# Altium schematic sheet Devices1.SchDoc(Devices1)
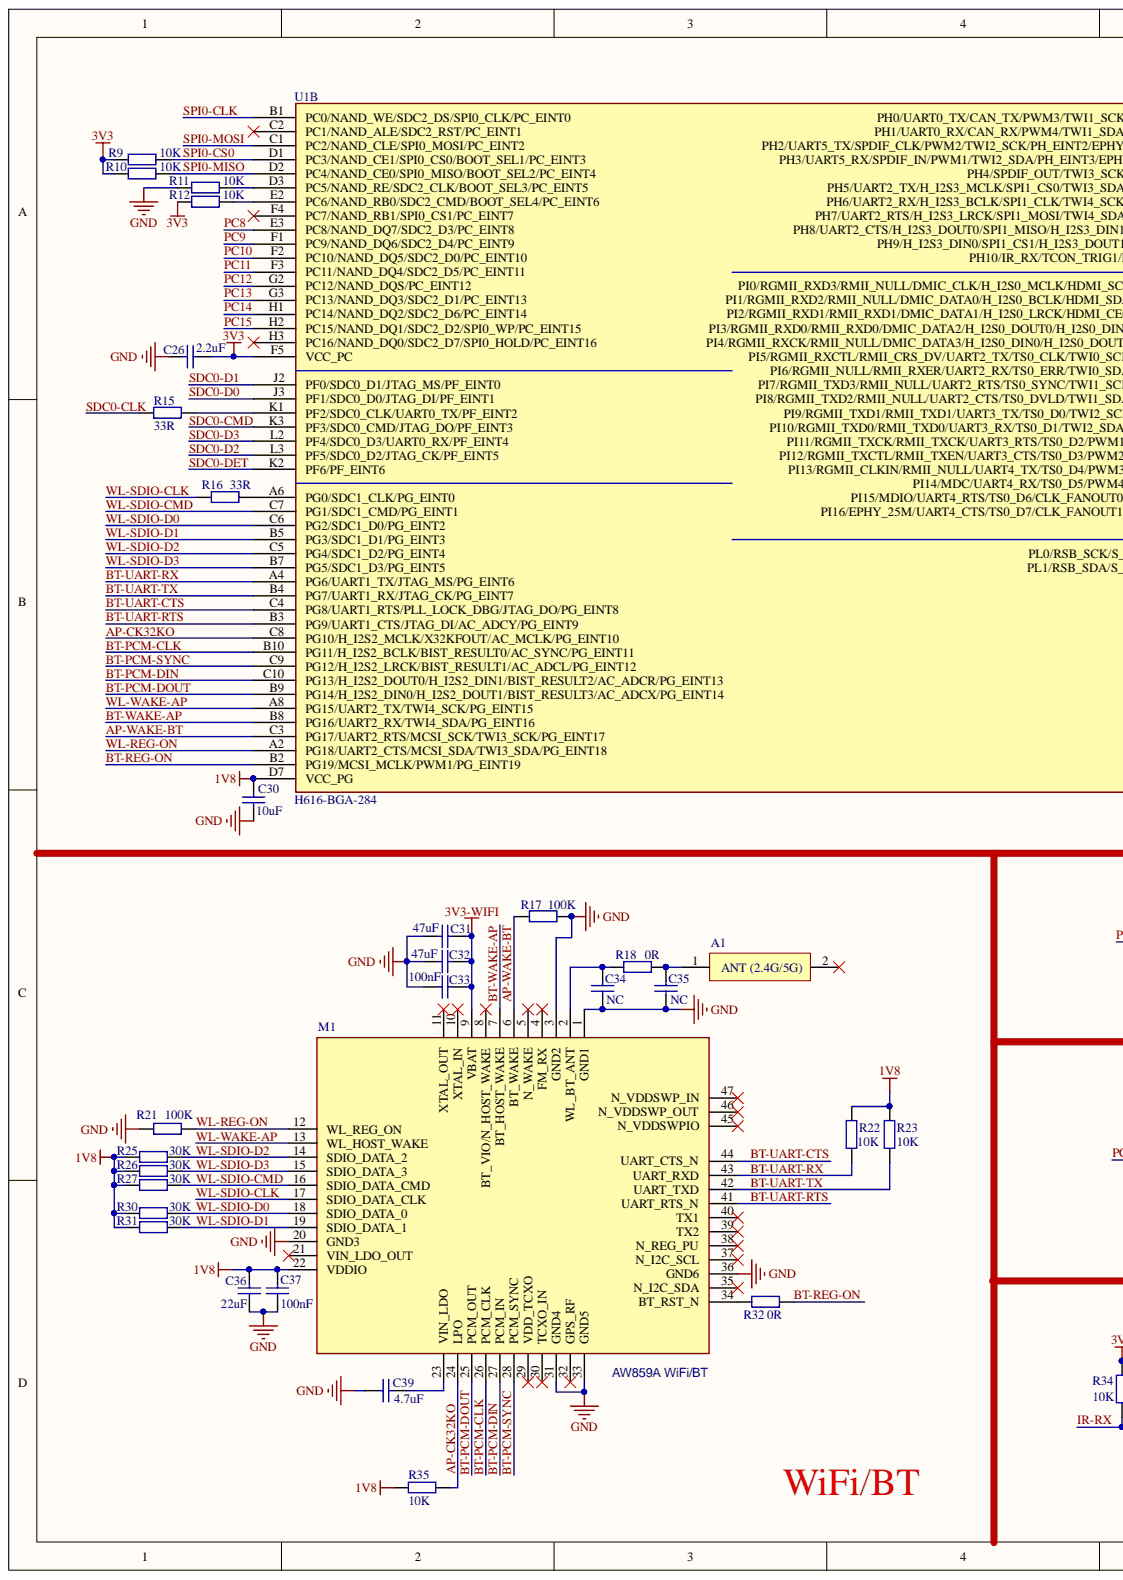
[diagram p0: Devices1.SchDoc, left]
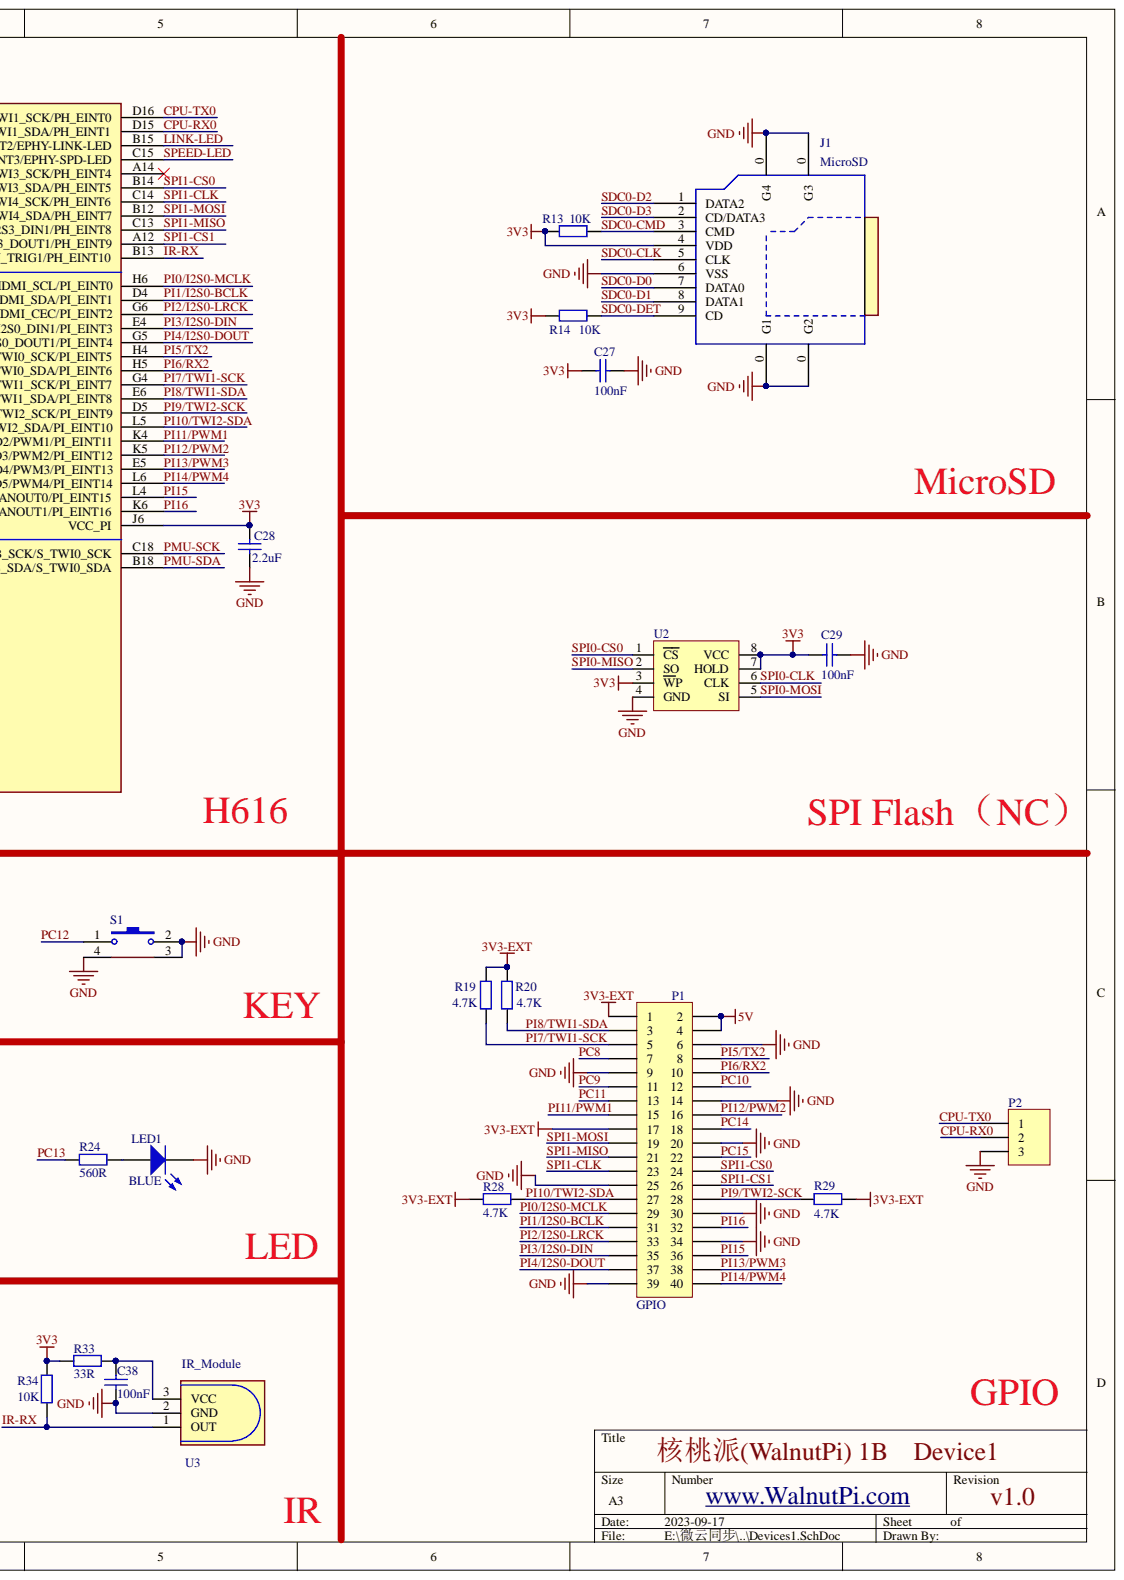
[diagram p1: Devices1.SchDoc, right]

## Parts
A1  (no value)  [OA-W01]
C26  2.2uF  [R0402]
C27  100nF  [R0402]
C28  2.2uF  [R0402]
C29  100nF  [R0402]
C30  10uF  [R0402]
C31  47uF  [R0603]
C32  47uF  [R0603]
C33  100nF  [R0402]
C34  NC  [R0402]
C35  NC  [R0402]
C36  22uF  [R0603]
C37  100nF  [R0402]
C38  100nF  [R0402]
C39  4.7uF  [R0402]
J1  MicroSD  [MicroSD卡]
LED1  BLUE  [D0603]
M1  AW859A WiFi/BT  [AW859A]
P1  GPIO  [HDR2X20（40P）]
P2  (no value)  [HDR1X3]
R9  10K  [R0402]
R10  10K  [R0402]
R11  10K  [R0402]
R12  10K  [R0402]
R13  10K  [R0402]
R14  10K  [R0402]
R15  33R  [R0402]
R16  33R  [R0402]
R17  100K  [R0402]
R18  0R  [R0402]
R19  4.7K  [R0402]
R20  4.7K  [R0402]
R21  100K  [R0402]
R22  10K  [R0402]
R23  10K  [R0402]
R24  560R  [R0402]
R25  30K  [R0402]
R26  30K  [R0402]
R27  30K  [R0402]
R28  4.7K  [R0402]
R29  4.7K  [R0402]
R30  30K  [R0402]
R31  30K  [R0402]
R32  0R  [R0402]
R33  33R  [R0402]
R34  10K  [R0402]
R35  10K  [R0402]
S1  (no value)  [3x6x3.5侧贴按键]
U1  H616-BGA-284  (part B)
U2  (no value)  [SOIC-8]
U3  IR_Module  [IR-RX]

## Nets
1V8: C30.2 C36.1 C37.2 M1.22(VDDIO) R22.1 R23.1 R25.2 R26.2 R27.2 R30.2 R31.2 R35.2 U1.D7(VCC_PG)
3V3: C26.2 C27.1 C28.2 C29.1 J1.4(VDD) R9.1 R10.1 R12.1 R13.2 R14.2 R33.1 R34.2 U1.F5(VCC_PC) U1.J6(VCC_PI) U2.3(WP) U2.7(HOLD) U2.8(VCC)
3V3-EXT: P1.1 P1.17 R19.2 R20.2 R28.2 R29.1
3V3-WIFI: C31.2 C32.2 C33.2 M1.9(VBAT)
5V: P1.2 P1.4
GND: C26.1 C27.2 C28.1 C29.2 C30.1 C31.1 C32.1 C33.1 C34.1 C35.1 C36.2 C37.1 C38.1 C39.1 J1.0(G4) J1.6(VSS) LED1.2 M1.1(GND1) M1.3(GND2) M1.20(GND3) M1.31(GND4) M1.33(GND5) M1.36(GND6) P1.6 P1.9 P1.14 P1.20 P1.25 P1.30 P1.34 P1.39 P2.3 R11.1 R17.1 R21.2 S1.2 S1.3 S1.4 U2.4(GND) U3.2
AP-CK32KO: M1.24(LPO) R35.1 U1.C8(PG10/H_I2S2_MCLK/X32KFOUT/AC_MCLK/PG_EINT10)
AP-WAKE-BT: M1.6(BT_WAKE) R17.2 U1.C3(PG17/UART2_RTS/MCSI_SCK/TWI3_SCK/PG_EINT17)
BT-PCM-CLK: M1.26(PCM_CLK) U1.B10(PG11/H_I2S2_BCLK/BIST_RESULT0/AC_SYNC/PG_EINT11)
BT-PCM-DIN: M1.27(PCM_IN) U1.C10(PG13/H_I2S2_DOUT0/H_I2S2_DIN1/BIST_RESULT2/AC_ADCR/PG_EINT13)
BT-PCM-DOUT: M1.25(PCM_OUT) U1.B9(PG14/H_I2S2_DIN0/H_I2S2_DOUT1/BIST_RESULT3/AC_ADCX/PG_EINT14)
BT-PCM-SYNC: M1.28(PCM_SYNC) U1.C9(PG12/H_I2S2_LRCK/BIST_RESULT1/AC_ADCL/PG_EINT12)
BT-REG-ON: R32.2 U1.B2(PG19/MCSI_MCLK/PWM1/PG_EINT19)
BT-UART-CTS: M1.44(UART_CTS_N) U1.C4(PG8/UART1_RTS/PLL_LOCK_DBG/JTAG_DO/PG_EINT8)
BT-UART-RTS: M1.41(UART_RTS_N) U1.B3(PG9/UART1_CTS/JTAG_DI/AC_ADCY/PG_EINT9)
BT-UART-RX: M1.43(UART_RXD) R22.2 U1.A4(PG6/UART1_TX/JTAG_MS/PG_EINT6)
BT-UART-TX: M1.42(UART_TXD) R23.2 U1.B4(PG7/UART1_RX/JTAG_CK/PG_EINT7)
BT-WAKE-AP: M1.7(BT_HOST_WAKE) U1.B8(PG16/UART2_RX/TWI4_SDA/PG_EINT16)
CPU-RX0: P2.2 U1.D15(PH1/UART0_RX/CAN_RX/PWM4/TWI1_SDA/PH_EINT1)
CPU-TX0: P2.1 U1.D16(PH0/UART0_TX/CAN_TX/PWM3/TWI1_SCK/PH_EINT0)
IR-RX: R34.1 U1.B13(PH10/IR_RX/TCON_TRIG1/PH_EINT10) U3.1
LINK-LED: U1.B15(PH2/UART5_TX/SPDIF_CLK/PWM2/TWI2_SCK/PH_EINT2/EPHY-LINK-LED)
PC8: P1.7 U1.E3(PC8/NAND_DQ7/SDC2_D3/PC_EINT8)
PC9: P1.11 U1.F1(PC9/NAND_DQ6/SDC2_D4/PC_EINT9)
PC10: P1.12 U1.F2(PC10/NAND_DQ5/SDC2_D0/PC_EINT10)
PC11: P1.13 U1.F3(PC11/NAND_DQ4/SDC2_D5/PC_EINT11)
PC12: S1.1 U1.G2(PC12/NAND_DQS/PC_EINT12)
PC13: R24.1 U1.G3(PC13/NAND_DQ3/SDC2_D1/PC_EINT13)
PC14: P1.18 U1.H1(PC14/NAND_DQ2/SDC2_D6/PC_EINT14)
PC15: P1.22 U1.H2(PC15/NAND_DQ1/SDC2_D2/SPI0_WP/PC_EINT15)
PI0/I2S0-MCLK: P1.29 U1.H6(PI0/RGMII_RXD3/RMII_NULL/DMIC_CLK/H_I2S0_MCLK/HDMI_SCL/PI_EINT0)
PI1/I2S0-BCLK: P1.31 U1.D4(PI1/RGMII_RXD2/RMII_NULL/DMIC_DATA0/H_I2S0_BCLK/HDMI_SDA/PI_EINT1)
PI2/I2S0-LRCK: P1.33 U1.G6(PI2/RGMII_RXD1/RMII_RXD1/DMIC_DATA1/H_I2S0_LRCK/HDMI_CEC/PI_EINT2)
PI3/I2S0-DIN: P1.35 U1.E4(PI3/RGMII_RXD0/RMII_RXD0/DMIC_DATA2/H_I2S0_DOUT0/H_I2S0_DIN1/PI_EINT3)
PI4/I2S0-DOUT: P1.37 U1.G5(PI4/RGMII_RXCK/RMII_NULL/DMIC_DATA3/H_I2S0_DIN0/H_I2S0_DOUT1/PI_EINT4)
PI5/TX2: P1.8 U1.H4(PI5/RGMII_RXCTL/RMII_CRS_DV/UART2_TX/TS0_CLK/TWI0_SCK/PI_EINT5)
PI6/RX2: P1.10 U1.H5(PI6/RGMII_NULL/RMII_RXER/UART2_RX/TS0_ERR/TWI0_SDA/PI_EINT6)
PI7/TWI1-SCK: P1.5 R19.1 U1.G4(PI7/RGMII_TXD3/RMII_NULL/UART2_RTS/TS0_SYNC/TWI1_SCK/PI_EINT7)
PI8/TWI1-SDA: P1.3 R20.1 U1.E6(PI8/RGMII_TXD2/RMII_NULL/UART2_CTS/TS0_DVLD/TWI1_SDA/PI_EINT8)
PI9/TWI2-SCK: P1.28 R29.2 U1.D5(PI9/RGMII_TXD1/RMII_TXD1/UART3_TX/TS0_D0/TWI2_SCK/PI_EINT9)
PI10/TWI2-SDA: P1.27 R28.1 U1.L5(PI10/RGMII_TXD0/RMII_TXD0/UART3_RX/TS0_D1/TWI2_SDA/PI_EINT10)
PI11/PWM1: P1.15 U1.K4(PI11/RGMII_TXCK/RMII_TXCK/UART3_RTS/TS0_D2/PWM1/PI_EINT11)
PI12/PWM2: P1.16 U1.K5(PI12/RGMII_TXCTL/RMII_TXEN/UART3_CTS/TS0_D3/PWM2/PI_EINT12)
PI13/PWM3: P1.38 U1.E5(PI13/RGMII_CLKIN/RMII_NULL/UART4_TX/TS0_D4/PWM3/PI_EINT13)
PI14/PWM4: P1.40 U1.L6(PI14/MDC/UART4_RX/TS0_D5/PWM4/PI_EINT14)
PI15: P1.36 U1.L4(PI15/MDIO/UART4_RTS/TS0_D6/CLK_FANOUT0/PI_EINT15)
PI16: P1.32 U1.K6(PI16/EPHY_25M/UART4_CTS/TS0_D7/CLK_FANOUT1/PI_EINT16)
PMU-SCK: U1.C18(PL0/RSB_SCK/S_TWI0_SCK)
PMU-SDA: U1.B18(PL1/RSB_SDA/S_TWI0_SDA)
SDC0-CLK: J1.5(CLK) R15.1
SDC0-CMD: J1.3(CMD) R13.1 U1.K3(PF3/SDC0_CMD/JTAG_DO/PF_EINT3)
SDC0-D0: J1.7(DATA0) U1.J3(PF1/SDC0_D0/JTAG_DI/PF_EINT1)
SDC0-D1: J1.8(DATA1) U1.J2(PF0/SDC0_D1/JTAG_MS/PF_EINT0)
SDC0-D2: J1.1(DATA2) U1.L3(PF5/SDC0_D2/JTAG_CK/PF_EINT5)
SDC0-D3: J1.2(CD/DATA3) U1.L2(PF4/SDC0_D3/UART0_RX/PF_EINT4)
SDC0-DET: J1.9(CD) R14.1 U1.K2(PF6/PF_EINT6)
SPEED-LED: U1.C15(PH3/UART5_RX/SPDIF_IN/PWM1/TWI2_SDA/PH_EINT3/EPHY-SPD-LED)
SPI0-CLK: U1.B1(PC0/NAND_WE/SDC2_DS/SPI0_CLK/PC_EINT0) U2.6(CLK)
SPI0-CS0: R9.2 U1.D1(PC3/NAND_CE1/SPI0_CS0/BOOT_SEL1/PC_EINT3) U2.1(CS)
SPI0-MISO: R10.2 U1.D2(PC4/NAND_CE0/SPI0_MISO/BOOT_SEL2/PC_EINT4) U2.2(SO)
SPI0-MOSI: U1.C1(PC2/NAND_CLE/SPI0_MOSI/PC_EINT2) U2.5(SI)
SPI1-CLK: P1.23 U1.C14(PH6/UART2_RX/H_I2S3_BCLK/SPI1_CLK/TWI4_SCK/PH_EINT6)
SPI1-CS0: P1.24 U1.B14(PH5/UART2_TX/H_I2S3_MCLK/SPI1_CS0/TWI3_SDA/PH_EINT5)
SPI1-CS1: P1.26 U1.A12(PH9/H_I2S3_DIN0/SPI1_CS1/H_I2S3_DOUT1/PH_EINT9)
SPI1-MISO: P1.21 U1.C13(PH8/UART2_CTS/H_I2S3_DOUT0/SPI1_MISO/H_I2S3_DIN1/PH_EINT8)
SPI1-MOSI: P1.19 U1.B12(PH7/UART2_RTS/H_I2S3_LRCK/SPI1_MOSI/TWI4_SDA/PH_EINT7)
WL-REG-ON: M1.12(WL_REG_ON) R21.1 U1.A2(PG18/UART2_CTS/MCSI_SDA/TWI3_SDA/PG_EINT18)
WL-SDIO-CLK: M1.17(SDIO_DATA_CLK) R16.1
WL-SDIO-CMD: M1.16(SDIO_DATA_CMD) R27.1 U1.C7(PG1/SDC1_CMD/PG_EINT1)
WL-SDIO-D0: M1.18(SDIO_DATA_0) R30.1 U1.C6(PG2/SDC1_D0/PG_EINT2)
WL-SDIO-D1: M1.19(SDIO_DATA_1) R31.1 U1.B5(PG3/SDC1_D1/PG_EINT3)
WL-SDIO-D2: M1.14(SDIO_DATA_2) R25.1 U1.C5(PG4/SDC1_D2/PG_EINT4)
WL-SDIO-D3: M1.15(SDIO_DATA_3) R26.1 U1.B7(PG5/SDC1_D3/PG_EINT5)
WL-WAKE-AP: M1.13(WL_HOST_WAKE) U1.A8(PG15/UART2_TX/TWI4_SCK/PG_EINT15)
NetA1_1: A1.1 C35.2 R18.1
NetC34_2: C34.2 M1.2(WL_BT_ANT) R18.2
NetC38_2: C38.2 R33.2 U3.3
NetC39_2: C39.2 M1.23(VIN_LDO)
NetLED1_1: LED1.1 R24.2
NetM1_34: M1.34(BT_RST_N) R32.1
NetR11_2: R11.2 U1.D3(PC5/NAND_RE/SDC2_CLK/BOOT_SEL3/PC_EINT5)
NetR12_2: R12.2 U1.E2(PC6/NAND_RB0/SDC2_CMD/BOOT_SEL4/PC_EINT6)
NetR15_2: R15.2 U1.K1(PF2/SDC0_CLK/UART0_TX/PF_EINT2)
NetR16_2: R16.2 U1.A6(PG0/SDC1_CLK/PG_EINT0)

Not connected: A1 2; M1 4(FM_RX) 5(N_WAKE) 8(BT_VIO/N_HOST_WAKE) 10(XTAL_IN) 11(XTAL_OUT) 21(VIN_LDO_OUT) 29(VDD_TCXO) 30(TCXO_IN) 32(GPS_RF) 35(N_I2C_SDA) 37(N_I2C_SCL) 38(N_REG_PU) 39(TX2) 40(TX1) 45(N_VDDSWPIO) 46(N_VDDSWP_OUT) 47(N_VDDSWP_IN); U1 A14(PH4/SPDIF_OUT/TWI3_SCK/PH_EINT4) C2(PC1/NAND_ALE/SDC2_RST/PC_EINT1) F4(PC7/NAND_RB1/SPI0_CS1/PC_EINT7) H3(PC16/NAND_DQ0/SDC2_D7/SPI0_HOLD/PC_EINT16)

## Library data of the parts
A1 = SMT_ANT_双频: Library Name: Schlib1.SchLib; Component Kind: Standard
C26-C39 = Cap: Capacitor; Simulation: CAP; Signal Integrity: Cap; Published: 8-Jun-2000; PackageReference: RAD-0.3; Library Name: Miscellaneous Devices.IntLib; LatestRevisionNote: Re-released for DXP Platform.; LatestRevisionDate: 17-Jul-2002; Component Kind: Standard; Publisher: Altium Limited
J1 = TF_SD_SOCKET_0: Library Name: Schlib1.SchLib; Component Kind: Standard
LED1 = LED0: Typical INFRARED GaAs LED; Simulation: LED0; Published: 8-Jun-2000; PackageReference: LED-0; Library Name: Miscellaneous Devices.IntLib; LatestRevisionNote: Mech Layer 1 removed.; LatestRevisionDate: 08-Jul-2005; Component Kind: Standard; Publisher: Altium Limited
M1 = AW859A: ORCAD_LIB: MODULE; Library Name: Schlib1.SchLib; Component Kind: Standard
P1 = Header 20X2: Header, 20-Pin, Dual row; Signal Integrity: Connector; Library Name: Miscellaneous Connectors.IntLib; LatestRevisionNote: Re-released for DXP Platform.; LatestRevisionDate: 17-Jul-2002; Component Kind: Standard; Publisher: Altium Limited
P2 = Header 3: Header, 3-Pin; Signal Integrity: Connector; Library Name: Miscellaneous Connectors.IntLib; LatestRevisionNote: Re-released for DXP Platform.; LatestRevisionDate: 17-Jul-2002; Component Kind: Standard; Publisher: Altium Limited
R9-R35 = Res2: Resistor; Simulation: RESISTOR; Signal Integrity: Res; Published: 8-Jun-2000; PackageReference: AXIAL-0.4; Library Name: Miscellaneous Devices.IntLib; LatestRevisionNote: Re-released for DXP Platform.; LatestRevisionDate: 17-Jul-2002; Component Kind: Standard; Publisher: Altium Limited
S1 = 侧按键(4P): Switch; Published: 24-Mar-1999; PackageReference: SPST-2; PackageDescription: Switch; 2 Leads; Library Name: Schlib1.SchLib; LatestRevisionNote: Re-released for DXP Platform.; LatestRevisionDate: 17-Jul-2002; Component Kind: Standard; Publisher: Altium Limited
U1 = H616-BGA-284: SWAP_INFO: (S1+S2+S3+S4); SPLIT_INST: TRUE; Library Name: Schlib1.SchLib; Component Kind: Standard
U2 = W25L16: Library Name: Schlib1.SchLib; Description: W25L16; Component Kind: Standard
U3 = IR_Module: Library Name: Schlib1.SchLib; Description: IR_MODULE; Component Kind: Standard
